# Altium schematic sheet Принципиальная схема ЕСКД.SchDoc
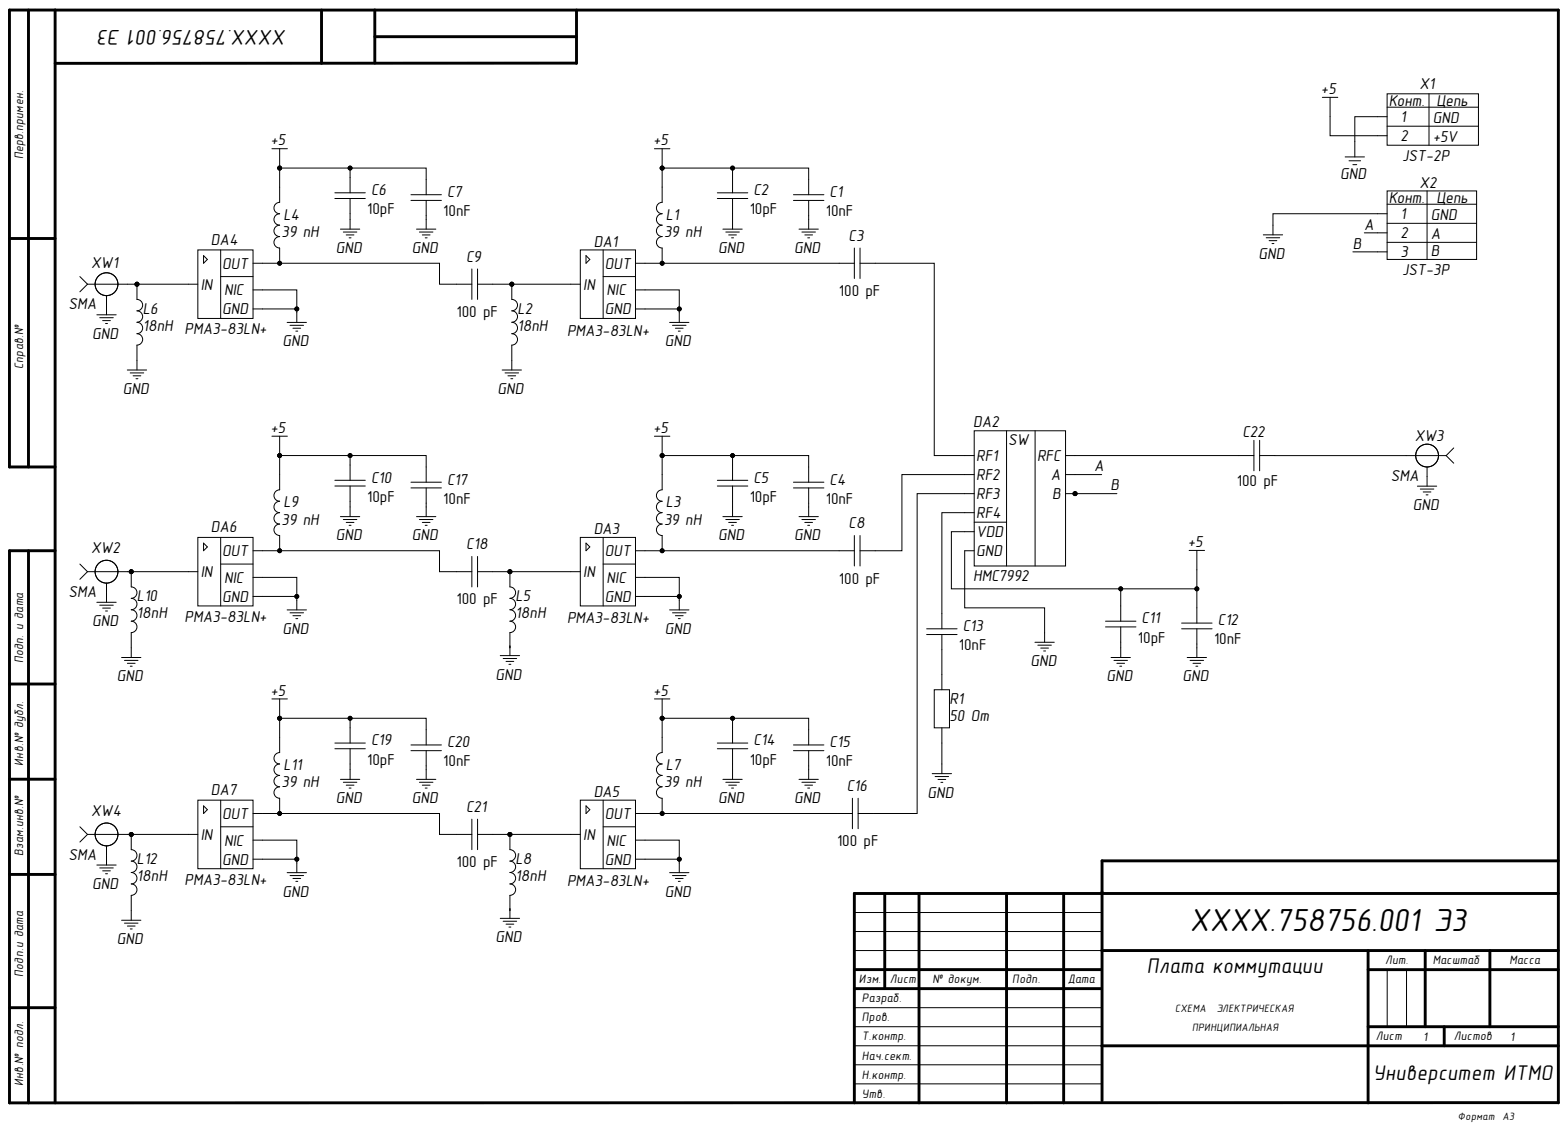
[diagram p0: Принципиальная схема ЕСКД.SchDoc]

## Parts
C1  10nF  [FP-GJM155-0_05-IPC_A]
C2  10pF  [FP-GJM155-0_05-IPC_A]
C3  100 pF  [FP-GJM155-0_05-IPC_A]
C4  10nF  [FP-GJM155-0_05-IPC_A]
C5  10pF  [FP-GJM155-0_05-IPC_A]
C6  10pF  [FP-GJM155-0_05-IPC_A]
C7  10nF  [FP-GJM155-0_05-IPC_A]
C8  100 pF  [FP-GJM155-0_05-IPC_A]
C9  100 pF  [FP-GJM155-0_05-IPC_A]
C10  10pF  [FP-GJM155-0_05-IPC_A]
C11  10pF  [FP-GJM155-0_05-IPC_A]
C12  10nF  [FP-GJM155-0_05-IPC_A]
C13  10nF  [FP-GJM155-0_05-IPC_A]
C14  10pF  [FP-GJM155-0_05-IPC_A]
C15  10nF  [FP-GJM155-0_05-IPC_A]
C16  100 pF  [FP-GJM155-0_05-IPC_A]
C17  10nF  [FP-GJM155-0_05-IPC_A]
C18  100 pF  [FP-GJM155-0_05-IPC_A]
C19  10pF  [FP-GJM155-0_05-IPC_A]
C20  10nF  [FP-GJM155-0_05-IPC_A]
C21  100 pF  [FP-GJM155-0_05-IPC_A]
C22  100 pF  [FP-GJM155-0_05-IPC_A]
DA1  PMA3-83LN+  [FP-DQ1225-IPC_A]
DA2  HMC7992  [FP-CP-16-38-IPC_A]
DA3  PMA3-83LN+  [FP-DQ1225-IPC_A]
DA4  PMA3-83LN+  [FP-DQ1225-IPC_A]
DA5  PMA3-83LN+  [FP-DQ1225-IPC_A]
DA6  PMA3-83LN+  [FP-DQ1225-IPC_A]
DA7  PMA3-83LN+  [FP-DQ1225-IPC_A]
L1  39 nH  [FP-0402HP-MFG]
L2  18nH  [FP-0402HP-MFG]
L3  39 nH  [FP-0402HP-MFG]
L4  39 nH  [FP-0402HP-MFG]
L5  18nH  [FP-0402HP-MFG]
L6  18nH  [FP-0402HP-MFG]
L7  39 nH  [FP-0402HP-MFG]
L8  18nH  [FP-0402HP-MFG]
L9  39 nH  [FP-0402HP-MFG]
L10  18nH  [FP-0402HP-MFG]
L11  39 nH  [FP-0402HP-MFG]
L12  18nH  [FP-0402HP-MFG]
R1  50 Om  [RES0805]
X1  JST-2P  Net1: GND  Net2: +5V  [FP-S2B-XH-A-1_LF_SN-MFG]
X2  JST-3P  Net1: GND  Net2: A  Net3: B  [FP-S3B-XH-A-1_LF_SN-MFG]
XW1  SMA  [S-P2152-19CQA]
XW2  SMA  [S-P2152-19CQA]
XW3  SMA  [S-P2152-19CQA]
XW4  SMA  [S-P2152-19CQA]

## Nets
+5: C1.1 C2.1 C4.1 C5.1 C6.1 C7.1 C10.1 C11.1 C12.1 C14.1 C15.1 C17.1 C19.1 C20.1 DA2.6(VDD) L1.2 L3.2 L4.2 L7.2 L9.2 L11.2 X1.2
GND: C1.2 C2.2 C4.2 C5.2 C6.2 C7.2 C10.2 C11.2 C12.2 C14.2 C15.2 C17.2 C19.2 C20.2 DA1.1,3,4,5,6,7,9,10(NIC) DA1.13(GND) DA2.2, 3, 5, 10, 11, 13, 14, 16, 17(GND) DA3.1,3,4,5,6,7,9,10(NIC) DA3.13(GND) DA4.1,3,4,5,6,7,9,10(NIC) DA4.13(GND) DA5.1,3,4,5,6,7,9,10(NIC) DA5.13(GND) DA6.1,3,4,5,6,7,9,10(NIC) DA6.13(GND) DA7.1,3,4,5,6,7,9,10(NIC) DA7.13(GND) L2.2 L5.2 L6.2 L8.2 L10.2 L12.2 R1.1 X1.1 X2.1 XW1.2 XW2.2 XW3.2 XW4.2
A: DA2.8(A) X2.2
B: DA2.7(B) X2.3
NetC3_1: C3.1 DA2.12(RF1)
NetC3_2: C3.2 DA1.8(OUT) L1.1
NetC8_1: C8.1 DA2.9(RF2)
NetC8_2: C8.2 DA3.8(OUT) L3.1
NetC9_1: C9.1 DA1.2(IN) L2.1
NetC9_2: C9.2 DA4.8(OUT) L4.1
NetC13_1: C13.1 DA2.1(RF4)
NetC13_2: C13.2 R1.2
NetC16_1: C16.1 DA2.4(RF3)
NetC16_2: C16.2 DA5.8(OUT) L7.1
NetC18_1: C18.1 DA3.2(IN) L5.1
NetC18_2: C18.2 DA6.8(OUT) L9.1
NetC21_1: C21.1 DA5.2(IN) L8.1
NetC21_2: C21.2 DA7.8(OUT) L11.1
NetC22_1: C22.1 XW3.1
NetC22_2: C22.2 DA2.15(RFC)
NetDA4_2: DA4.2(IN) L6.1 XW1.1
NetDA6_2: DA6.2(IN) L10.1 XW2.1
NetDA7_2: DA7.2(IN) L12.1 XW4.1

## Library data of the parts
C1-C22 = C: Component Kind: Standard; Library Name: kasp_komut_lib.SchLib
L1-L12 = L: Component Kind: Standard; Library Name: kasp_komut_lib.SchLib
R1 = R: Component Kind: Standard; Library Name: kasp_komut_lib.SchLib
X1 = JST-2P: JST type connector; Component Kind: Standard; Library Name: kasp_komut_lib.SchLib
X2 = JST-3P: JST type connector; Component Kind: Standard; Library Name: kasp_komut_lib.SchLib
DA1 DA3-DA7 = PMA3-83LN+: ClassName: AMP1; Component Kind: Standard; Library Name: kasp_komut_lib.SchLib
DA2 = HMC7992: Component Kind: Standard; Library Name: kasp_komut_lib.SchLib
XW1-XW4 = SMA: Component Kind: Standard; Library Name: kasp_komut_lib.SchLib
  XW1 XW2 XW4: ClassName: AMP1
  XW3: ClassName: AMP4
